# Altium schematic sheet 04)ADC.SchDoc(04)ADC)
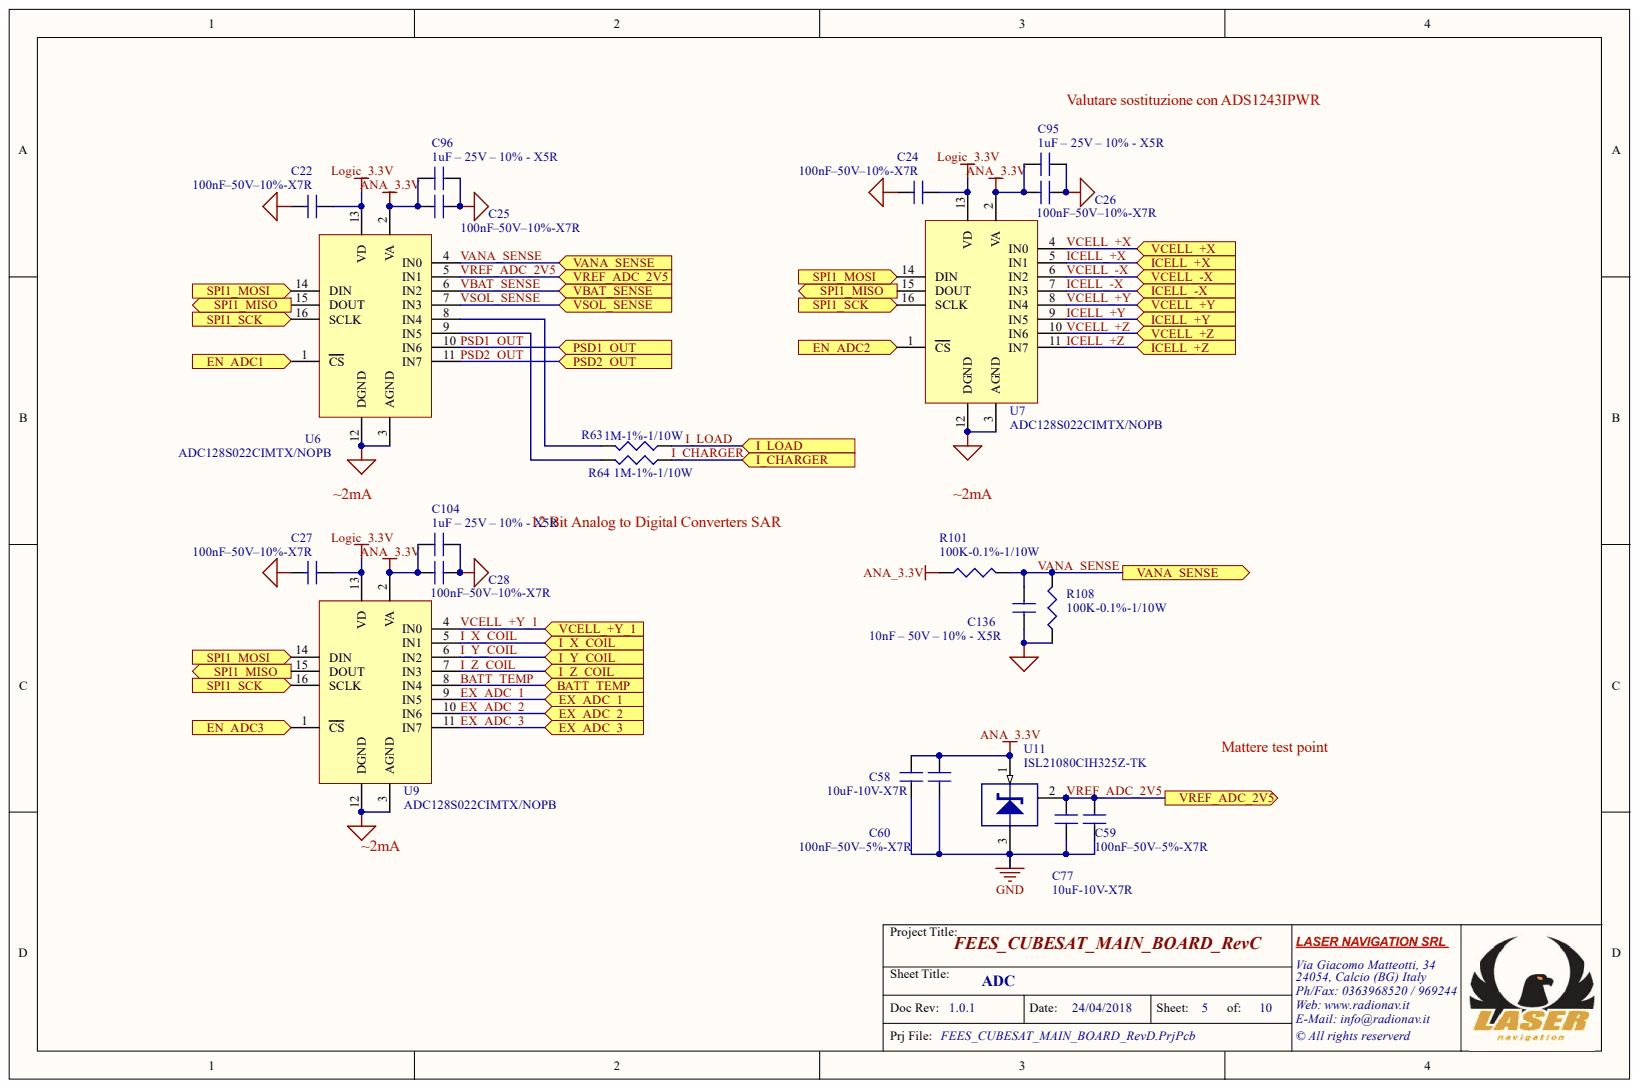
[diagram p0: 04)ADC.SchDoc]

## Parts
C22  100nF–50V–10%-X7R  [0603_CAP]
C24  100nF–50V–10%-X7R  [0603_CAP]
C25  100nF–50V–10%-X7R  [0603_CAP]
C26  100nF–50V–10%-X7R  [0603_CAP]
C27  100nF–50V–10%-X7R  [0603_CAP]
C28  100nF–50V–10%-X7R  [0603_CAP]
C58  10uF-10V-X7R  [0805_CAP]
C59  100nF–50V–5%-X7R  [0603_CAP]
C60  100nF–50V–5%-X7R  [0603_CAP]
C77  10uF-10V-X7R  [0805_CAP]
C95  1uF – 25V – 10% - X5R  [0603_CAP]
C96  1uF – 25V – 10% - X5R  [0603_CAP]
C104  1uF – 25V – 10% - X5R  [0603_CAP]
C136  10nF – 50V – 10% - X5R  [0603_CAP]
R63  1M-1%-1/10W  [0603_RES]
R64  1M-1%-1/10W  [0603_RES]
R101  100K-0.1%-1/10W  [0603_RES]
R108  100K-0.1%-1/10W  [0603_RES]
U6  ADC128S022CIMTX/NOPB  [TSSOP16]
U7  ADC128S022CIMTX/NOPB  [TSSOP16]
U9  ADC128S022CIMTX/NOPB  [TSSOP16]
U11  ISL21080CIH325Z-TK  [SOT23]

## Ports
BATT_TEMP, EN_ADC1, EN_ADC2, EN_ADC3, EX_ADC_1, EX_ADC_2, EX_ADC_3, I_CHARGER, I_LOAD, I_X_COIL, I_Y_COIL, I_Z_COIL, ICELL_+X, ICELL_+Y, ICELL_+Z, ICELL_-X, PSD1_OUT, PSD2_OUT, SPI1_MISO, SPI1_MOSI, SPI1_SCK, VANA_SENSE, VBAT_SENSE, VCELL_+X, VCELL_+Y, VCELL_+Y_1, VCELL_+Z, VCELL_-X, VREF_ADC_2V5, VSOL_SENSE

## Nets
ANA_3.3V: C25.1 C26.1 C28.1 C58.2 C60.1 C95.1 C96.1 C104.1 R101.1 U6.2(VA) U7.2(VA) U9.2(VA) U11.1
GND: C22.2 C24.2 C25.2 C26.2 C27.2 C28.2 C58.1 C59.2 C60.2 C77.1 C95.2 C96.2 C104.2 C136.1 R108.1 U6.3(AGND) U6.12(DGND) U7.3(AGND) U7.12(DGND) U9.3(AGND) U9.12(DGND) U11.3
LOGIC_3.3V: C22.1 C24.1 C27.1 U6.13(VD) U7.13(VD) U9.13(VD)
BATT_TEMP: U9.8(IN4) ; port BATT_TEMP
EN_ADC1: U6.1(CS) ; port EN_ADC1
EN_ADC2: U7.1(CS) ; port EN_ADC2
EN_ADC3: U9.1(CS) ; port EN_ADC3
EX_ADC_1: U9.9(IN5) ; port EX_ADC_1
EX_ADC_2: U9.10(IN6) ; port EX_ADC_2
EX_ADC_3: U9.11(IN7) ; port EX_ADC_3
I_CHARGER: R64.1 ; port I_CHARGER
I_LOAD: R63.1 ; port I_LOAD
I_X_COIL: U9.5(IN1) ; port I_X_COIL
I_Y_COIL: U9.6(IN2) ; port I_Y_COIL
I_Z_COIL: U9.7(IN3) ; port I_Z_COIL
ICELL_+X: U7.5(IN1) ; port ICELL_+X
ICELL_+Y: U7.9(IN5) ; port ICELL_+Y
ICELL_+Z: U7.11(IN7) ; port ICELL_+Z
ICELL_-X: U7.7(IN3) ; port ICELL_-X
PSD1_OUT: U6.10(IN6) ; port PSD1_OUT
PSD2_OUT: U6.11(IN7) ; port PSD2_OUT
SPI1_MISO: U6.15(DOUT) U7.15(DOUT) U9.15(DOUT) ; port SPI1_MISO
SPI1_MOSI: U6.14(DIN) U7.14(DIN) U9.14(DIN) ; port SPI1_MOSI
SPI1_SCK: U6.16(SCLK) U7.16(SCLK) U9.16(SCLK) ; port SPI1_SCK
VANA_SENSE: C136.2 R101.2 R108.2 U6.4(IN0) ; port VANA_SENSE
VBAT_SENSE: U6.6(IN2) ; port VBAT_SENSE
VCELL_+X: U7.4(IN0) ; port VCELL_+X
VCELL_+Y: U7.8(IN4) ; port VCELL_+Y
VCELL_+Y_1: U9.4(IN0) ; port VCELL_+Y_1
VCELL_+Z: U7.10(IN6) ; port VCELL_+Z
VCELL_-X: U7.6(IN2) ; port VCELL_-X
VREF_ADC_2V5: C59.1 C77.2 U6.5(IN1) U11.2 ; port VREF_ADC_2V5
VSOL_SENSE: U6.7(IN3) ; port VSOL_SENSE
NetR63_2: R63.2 U6.8(IN4)
NetR64_2: R64.2 U6.9(IN5)

## Library data of the parts
C22 C24-C28 = CAP: Non polarized capacitor; Component Kind: Standard; Library Name: User Sch Library.SchLib
C58 C77 = CAP: Non polarized capacitor; 1.Part Number: CC0805KKX7R6BB106; 2.Manufacturer: Yageo; 3.Description: CAP CER 10UF 10V X7R 0805; 5.Mounting: Y; 4.Supplier: Digikey 311-1879-1-ND; Component Kind: Standard; Library Name: User Sch Library.SchLib
C59 C60 = CAP: Non polarized capacitor; 1.Part Number: CC0603JRX7R9BB104; 2.Manufacturer: Yageo; 3.Description: CAP CER 0.1UF 50V X7R 0603; 5.Mounting: Y; 4.Supplier: Digikey 311-1779-1-ND; Component Kind: Standard; Library Name: User Sch Library.SchLib
C95 C96 C104 = CAP: Non polarized capacitor; 1.Part Number: CL10A105KA8NNNC; 2.Manufacturer: Samsung; 3.Description: CAP CER 1UF 25V 10% X5R 0603; 5.Mounting: Y; 4.Supplier: Digikey 1276-1102-1-ND; Component Kind: Standard; Library Name: User Sch Library.SchLib
C136 = CAP: Non polarized capacitor; 5.Mounting: Y; Component Kind: Standard; Library Name: User Sch Library.SchLib
R63 R64 = R: 5.Mounting: Y; Component Kind: Standard; Library Name: User Sch Library.SchLib
R101 R108 = R: 1.Part Number: AT0603BRD07100KL; 2.Manufacturer: Yageo; 3.Description: RES SMD 100K OHM 0.1% 1/10W 0603; 5.Mounting: Y; 4.Supplier: Digikey YAG2107CT-ND; Component Kind: Standard; Library Name: User Sch Library.SchLib
U6 U7 U9 = ADC128S: 8ch ADC National; 2.Manufacturer: Texas Instruments; 3.Description: 12 Bit Analog to Digital Converter 8 Input 1 SAR 16-TSSOP; 5.Mounting: Y; 1.Part Number: ADC128S022CIMTX/NOPB; 4.Supplier: Digikey  296-38561-1-ND; Component Kind: Standard; Library Name: User Sch Library.SchLib
U11 = ISL21080: Voltage Reference; 2.Manufacturer: Intersil; 3.Description: Series Voltage Reference IC ±0.3% 7mA SOT-23-3; 5.Mounting: Y; 1.Part Number: ISL21080CIH325Z-TK; 4.Supplier: Digikey ISL21080CIH325Z-TKCT-ND; Component Kind: Standard; Library Name: User Sch Library.SchLib
